# Altium schematic sheet Schematic.SchDoc
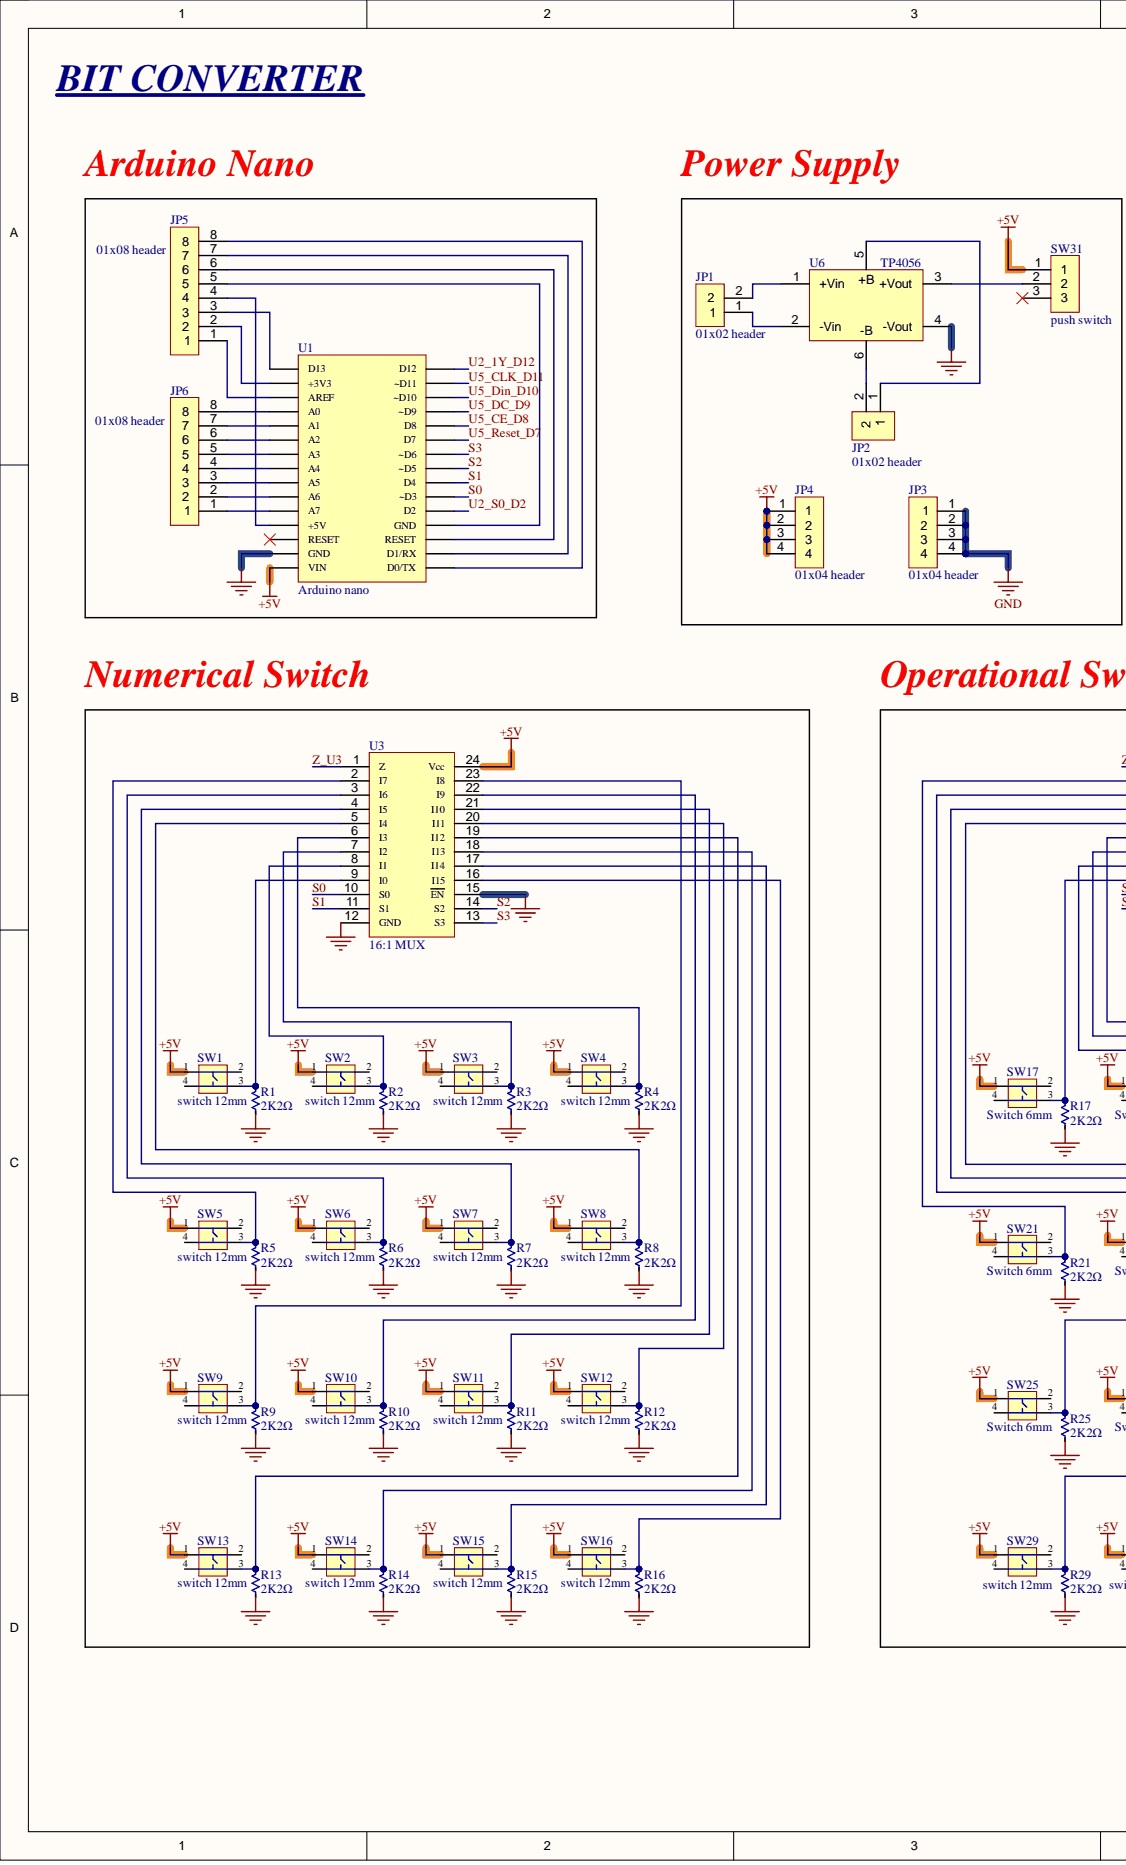
[diagram p0: Schematic.SchDoc, left]
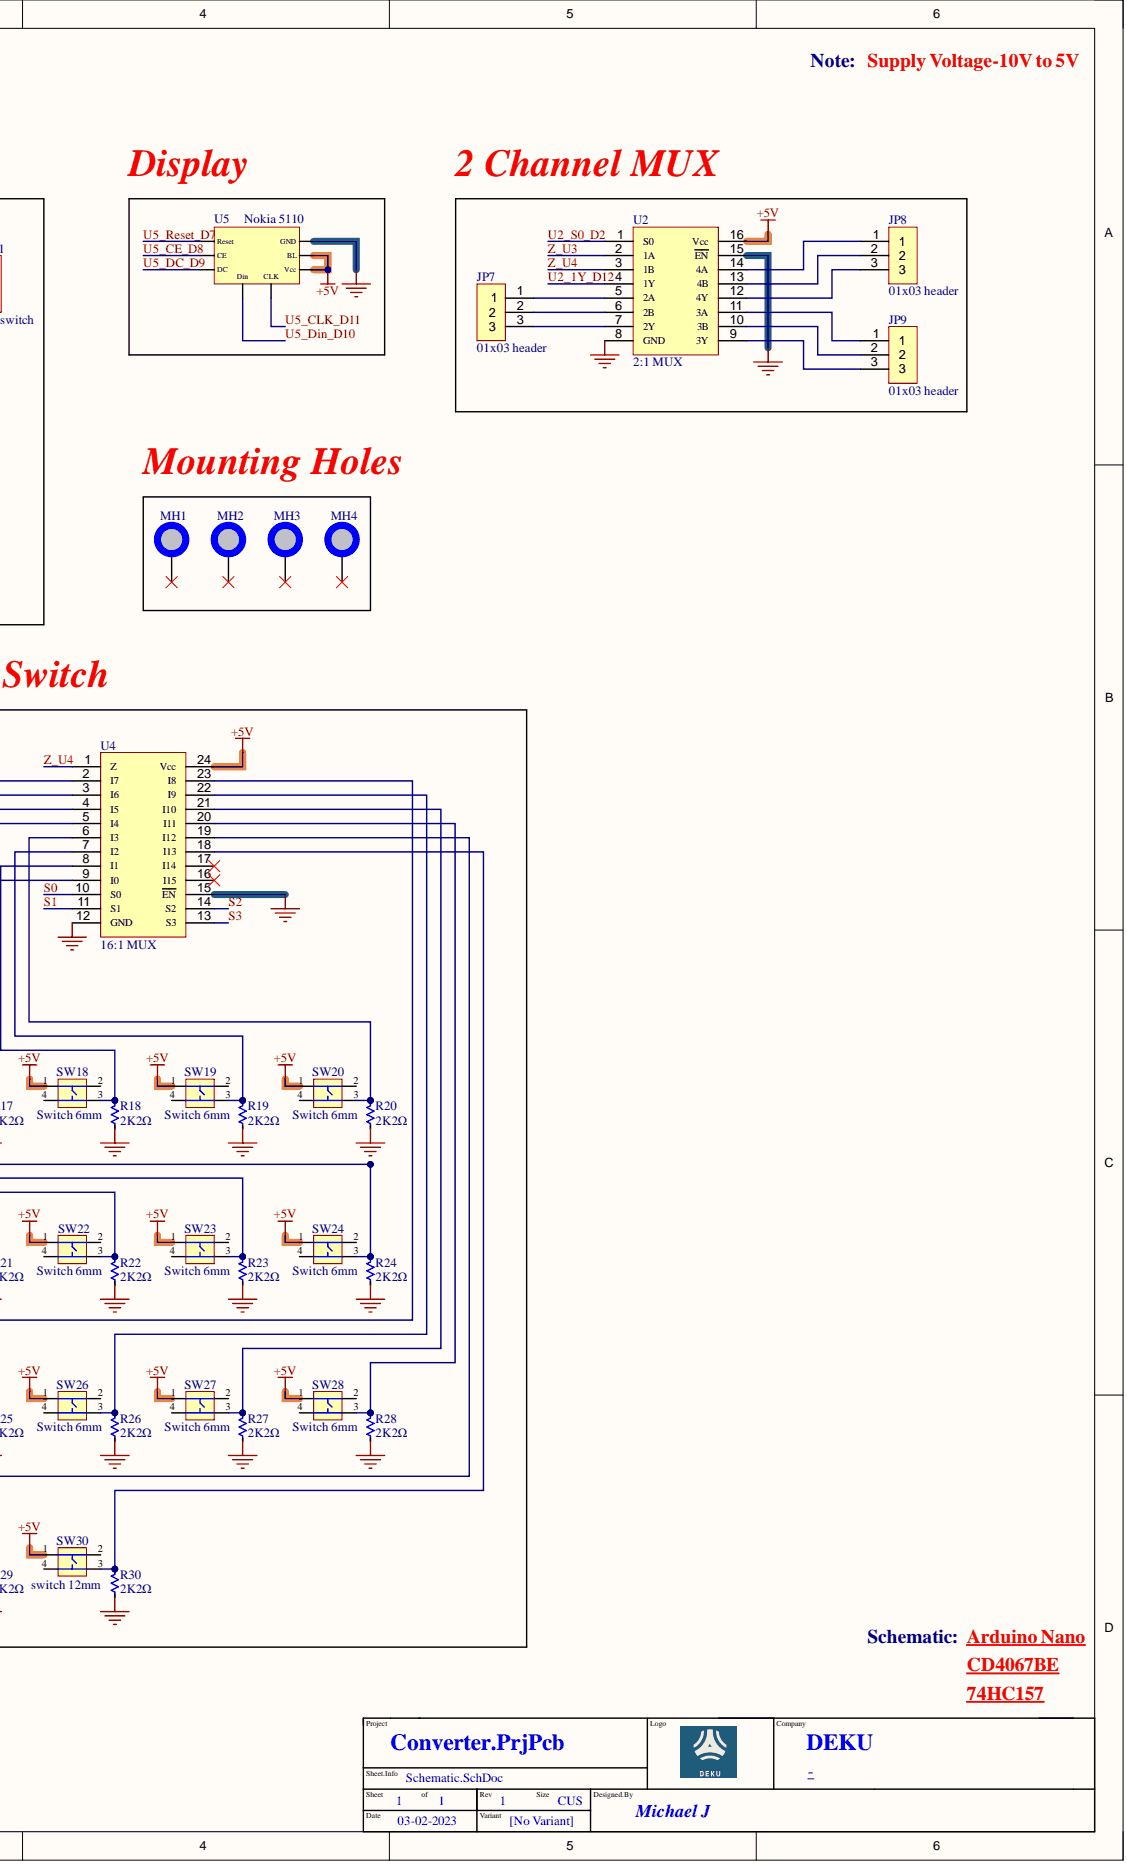
[diagram p1: Schematic.SchDoc, right]

## Parts
JP1  01x02 header  [01x02 header]
JP2  01x02 header  [01x02 header]
JP3  01x04 header  [01x04 header]
JP4  01x04 header  [01x04 header]
JP5  01x08 header  [01x08 header]
JP6  01x08 header  [01x08 header]
JP7  01x03 header  [01x03 header]
JP8  01x03 header  [01x03 header]
JP9  01x03 header  [01x03 header]
MH1  Mounting_Hole_NP_7.4mm_3.2mmNPD  [Mounting_Hole_NP_7.4mm_3.2mmNPD]  (value not drawn)  (no BOM)
MH2  Mounting_Hole_NP_7.4mm_3.2mmNPD  [Mounting_Hole_NP_7.4mm_3.2mmNPD]  (value not drawn)  (no BOM)
MH3  Mounting_Hole_NP_7.4mm_3.2mmNPD  [Mounting_Hole_NP_7.4mm_3.2mmNPD]  (value not drawn)  (no BOM)
MH4  Mounting_Hole_NP_7.4mm_3.2mmNPD  [Mounting_Hole_NP_7.4mm_3.2mmNPD]  (value not drawn)  (no BOM)
R1  2K2Ω  [2.2k]
R2  2K2Ω  [2.2k]
R3  2K2Ω  [2.2k]
R4  2K2Ω  [2.2k]
R5  2K2Ω  [2.2k]
R6  2K2Ω  [2.2k]
R7  2K2Ω  [2.2k]
R8  2K2Ω  [2.2k]
R9  2K2Ω  [2.2k]
R10  2K2Ω  [2.2k]
R11  2K2Ω  [2.2k]
R12  2K2Ω  [2.2k]
R13  2K2Ω  [2.2k]
R14  2K2Ω  [2.2k]
R15  2K2Ω  [2.2k]
R16  2K2Ω  [2.2k]
R17  2K2Ω  [2.2k]
R18  2K2Ω  [2.2k]
R19  2K2Ω  [2.2k]
R20  2K2Ω  [2.2k]
R21  2K2Ω  [2.2k]
R22  2K2Ω  [2.2k]
R23  2K2Ω  [2.2k]
R24  2K2Ω  [2.2k]
R25  2K2Ω  [2.2k]
R26  2K2Ω  [2.2k]
R27  2K2Ω  [2.2k]
R28  2K2Ω  [2.2k]
R29  2K2Ω  [2.2k]
R30  2K2Ω  [2.2k]
SW1  switch 12mm  Length: 12mm  [switch 12mm]
SW2  switch 12mm  Length: 12mm  [switch 12mm]
SW3  switch 12mm  Length: 12mm  [switch 12mm]
SW4  switch 12mm  Length: 12mm  [switch 12mm]
SW5  switch 12mm  Length: 12mm  [switch 12mm]
SW6  switch 12mm  Length: 12mm  [switch 12mm]
SW7  switch 12mm  Length: 12mm  [switch 12mm]
SW8  switch 12mm  Length: 12mm  [switch 12mm]
SW9  switch 12mm  Length: 12mm  [switch 12mm]
SW10  switch 12mm  Length: 12mm  [switch 12mm]
SW11  switch 12mm  Length: 12mm  [switch 12mm]
SW12  switch 12mm  Length: 12mm  [switch 12mm]
SW13  switch 12mm  Length: 12mm  [switch 12mm]
SW14  switch 12mm  Length: 12mm  [switch 12mm]
SW15  switch 12mm  Length: 12mm  [switch 12mm]
SW16  switch 12mm  Length: 12mm  [switch 12mm]
SW17  Switch 6mm  [switch 6mm]
SW18  Switch 6mm  [switch 6mm]
SW19  Switch 6mm  [switch 6mm]
SW20  Switch 6mm  [switch 6mm]
SW21  Switch 6mm  [switch 6mm]
SW22  Switch 6mm  [switch 6mm]
SW23  Switch 6mm  [switch 6mm]
SW24  Switch 6mm  [switch 6mm]
SW25  Switch 6mm  [switch 6mm]
SW26  Switch 6mm  [switch 6mm]
SW27  Switch 6mm  [switch 6mm]
SW28  Switch 6mm  [switch 6mm]
SW29  switch 12mm  Length: 12mm  [switch 12mm]
SW30  switch 12mm  Length: 12mm  [switch 12mm]
SW31  push switch  [push switch]
U1  Arduino nano  Manufacturer: Arduino  [Arduino nano]
U2  2:1 MUX  [2 channel mux]
U3  16:1 MUX  [16 channel mux]
U4  16:1 MUX  [16 channel mux]
U5  Nokia 5110  [nokia 5110]
U6  TP4056  [TP4056]

## Nets
+5V: JP4.1 JP4.2 JP4.3 JP4.4 SW1.1 SW2.1 SW3.1 SW4.1 SW5.1 SW6.1 SW7.1 SW8.1 SW9.1 SW10.1 SW11.1 SW12.1 SW13.1 SW14.1 SW15.1 SW16.1 SW17.1 SW18.1 SW19.1 SW20.1 SW21.1 SW22.1 SW23.1 SW24.1 SW25.1 SW26.1 SW27.1 SW28.1 SW29.1 SW30.1 SW31.1 U1.30(VIN) U2.16(Vcc) U3.24(Vcc) U4.24(Vcc) U5.6(Vcc) U5.7(BL)
GND: JP3.1 JP3.2 JP3.3 JP3.4 R1.1 R2.1 R3.1 R4.1 R5.1 R6.1 R7.1 R8.1 R9.1 R10.1 R11.1 R12.1 R13.1 R14.1 R15.1 R16.1 R17.1 R18.1 R19.1 R20.1 R21.1 R22.1 R23.1 R24.1 R25.1 R26.1 R27.1 R28.1 R29.1 R30.1 U1.29(GND) U2.8(GND) U2.15(EN) U3.12(GND) U3.15(EN) U4.12(GND) U4.15(EN) U5.8(GND) U6.4(-Vout)
S0: U1.6(~D3) U3.10(S0) U4.10(S0)
S1: U1.7(D4) U3.11(S1) U4.11(S1)
S2: U1.8(~D5) U3.14(S2) U4.14(S2)
S3: U1.9(~D6) U3.13(S3) U4.13(S3)
U2_1Y_D12: U1.15(D12) U2.4(1Y)
U2_S0_D2: U1.5(D2) U2.1(S0)
U5_CE_D8: U1.11(D8) U5.2(CE)
U5_CLK_D11: U1.14(~D11) U5.5
U5_DC_D9: U1.12(~D9) U5.3(DC)
U5_Din_D10: U1.13(~D10) U5.4
U5_Reset_D7: U1.10(D7) U5.1(Reset)
Z_U3: U2.2(1A) U3.1(Z)
Z_U4: U2.3(1B) U4.1(Z)
NetJP1_1: JP1.1 U6.2(-Vin)
NetJP1_2: JP1.2 U6.1(+Vin)
NetJP2_1: JP2.1 U6.5(+B)
NetJP2_2: JP2.2 U6.6(-B)
NetJP5_1: JP5.1 U1.18(AREF)
NetJP5_2: JP5.2 U1.17(+3V3)
NetJP5_3: JP5.3 U1.16(D13)
NetJP5_4: JP5.4 U1.27(+5V)
NetJP5_5: JP5.5 U1.4(GND)
NetJP5_6: JP5.6 U1.3(RESET)
NetJP5_7: JP5.7 U1.2(D1/RX)
NetJP5_8: JP5.8 U1.1(D0/TX)
NetJP6_1: JP6.1 U1.26(A7)
NetJP6_2: JP6.2 U1.25(A6)
NetJP6_3: JP6.3 U1.24(A5)
NetJP6_4: JP6.4 U1.23(A4)
NetJP6_5: JP6.5 U1.22(A3)
NetJP6_6: JP6.6 U1.21(A2)
NetJP6_7: JP6.7 U1.20(A1)
NetJP6_8: JP6.8 U1.19(A0)
NetJP7_1: JP7.1 U2.5(2A)
NetJP7_2: JP7.2 U2.6(2B)
NetJP7_3: JP7.3 U2.7(2Y)
NetJP8_1: JP8.1 U2.14(4A)
NetJP8_2: JP8.2 U2.13(4B)
NetJP8_3: JP8.3 U2.12(4Y)
NetJP9_1: JP9.1 U2.11(3A)
NetJP9_2: JP9.2 U2.10(3B)
NetJP9_3: JP9.3 U2.9(3Y)
NetR1_2: R1.2 SW1.3 U3.9(I0)
NetR2_2: R2.2 SW2.3 U3.8(I1)
NetR3_2: R3.2 SW3.3 U3.7(I2)
NetR4_2: R4.2 SW4.3 U3.6(I3)
NetR5_2: R5.2 SW5.3 U3.2(I7)
NetR6_2: R6.2 SW6.3 U3.3(I6)
NetR7_2: R7.2 SW7.3 U3.4(I5)
NetR8_2: R8.2 SW8.3 U3.5(I4)
NetR9_2: R9.2 SW9.3 U3.23(I8)
NetR10_2: R10.2 SW10.3 U3.22(I9)
NetR11_2: R11.2 SW11.3 U3.21(I10)
NetR12_2: R12.2 SW12.3 U3.20(I11)
NetR13_2: R13.2 SW13.3 U3.19(I12)
NetR14_2: R14.2 SW14.3 U3.18(I13)
NetR15_2: R15.2 SW15.3 U3.17(I14)
NetR16_2: R16.2 SW16.3 U3.16(I15)
NetR17_2: R17.2 SW17.3 U4.9(I0)
NetR18_2: R18.2 SW18.3 U4.8(I1)
NetR19_2: R19.2 SW19.3 U4.7(I2)
NetR20_2: R20.2 SW20.3 U4.6(I3)
NetR21_2: R21.2 SW21.3 U4.2(I7)
NetR22_2: R22.2 SW22.3 U4.3(I6)
NetR23_2: R23.2 SW23.3 U4.4(I5)
NetR24_2: R24.2 SW24.3 U4.5(I4)
NetR25_2: R25.2 SW25.3 U4.23(I8)
NetR26_2: R26.2 SW26.3 U4.22(I9)
NetR27_2: R27.2 SW27.3 U4.21(I10)
NetR28_2: R28.2 SW28.3 U4.20(I11)
NetR29_2: R29.2 SW29.3 U4.19(I12)
NetR30_2: R30.2 SW30.3 U4.18(I13)
NetSW31_2: SW31.2 U6.3(+Vout)

Not connected: MH1 1; MH2 1; MH3 1; MH4 1; SW1 2 4; SW2 2 4; SW3 2 4; SW4 2 4; SW5 2 4; SW6 2 4; SW7 2 4; SW8 2 4; SW9 2 4; SW10 2 4; SW11 2 4; SW12 2 4; SW13 2 4; SW14 2 4; SW15 2 4; SW16 2 4; SW17 2 4; SW18 2 4; SW19 2 4; SW20 2 4; SW21 2 4; SW22 2 4; SW23 2 4; SW24 2 4; SW25 2 4; SW26 2 4; SW27 2 4; SW28 2 4; SW29 2 4; SW30 2 4; SW31 3; U1 28(RESET); U4 16(I15) 17(I14)

## Library data of the parts
MH1-MH4 = Mounting_Hole_NP_7.4mm_3.2mmNPD: Mounting_Hole_NonPlated_7.4mm_3.2mmNonPlatedDrill; Library Name: Arduino_Uno_V1.1.IntLib
R1-R30 = 2K2Ω: RES 2.2K OHM 1/4W 5% AXIAL; Manufacturer: Yageo; Manufacturer Part Number: CFR-25JB-52-2K2; Max Power Dissipation: 250mW; Resistance: 2.2kR; Temperature Coefficient: 500ppm/°C; Case/Package: Axial; Category: Through-Hole Resistors; Component Kind: Standard; ComponentLink1Description: http://www.yageo.com/NewPortal/yageodocoutput?fileName=/pdf/throughhole/Yageo_LR_CFR_2013.pdf&src-supplier=Digi-Key; ComponentLink1URL: http://www.yageo.com/NewPortal/yageodocoutput?fileName=/pdf/throughhole/Yageo_LR_CFR_2013.pdf&src-supplier=Digi-Key; Lead Free: Lead Free; Length: 0.248inch; Library Name: Schlib1.SchLib; Max Operating Temperature: 155°C; Min Operating Temperature: -55°C; Mount: Through Hole; Number of Terminations: 2; Package Quantity: 200; Packaging: Bulk; Pricing 1: 1=0.10000, 10=0.06700, 25=0.04640, 50=0.03600, 100=0.02580, 250=0.02008, 500=0.01596, 1000=0.01133, 5000=0.00876 (USD); Resistor Type: Carbon Film; RoHS: Yes; RoHS Compliant: Yes; Series: Carbon Film; Stock 1: 57847; Supplier 1: Digi-Key; Supplier Part Number 1: 2.2KQBK-ND; Tolerance: 5%
SW1-SW16 SW29 SW30 = switch 12mm: Switch Tactile N.O. SPST Flat Plunger PC Pins 0.05A 24VDC 1.27N Thru-Hole Bag; Manufacturer: Omron; Manufacturer Part Number: B3F-5001; Actuator Color: Blue; Actuator Length: 0.8mm; Actuator Type: Standard; Contact Current Rating: 50mA; Contact Resistance: 100mR; Electrical Life: 10000000Cycles; Illumination Type: Non-Illuminated,Illuminated; Max Voltage Rating (DC): 24V; Number of Poles: 1; Ratings: IP00; Switch Function: Off-Mom; Switch Travel: 0.3mm; Category: Tactile Switches; Component Kind: Standard; ComponentLink1Description: https://datasheet.ciiva.com/2901/b3f-0811-2901588.pdf?src-supplier=Digi-Key; ComponentLink1URL: https://datasheet.ciiva.com/2901/b3f-0811-2901588.pdf?src-supplier=Digi-Key; ComponentLink2Description: https://datasheet.ciiva.com/16154/en-b3f-16154917.pdf?src-supplier=Onlinecomponents.com; ComponentLink2URL: https://datasheet.ciiva.com/16154/en-b3f-16154917.pdf?src-supplier=Onlinecomponents.com; ComponentLink3Description: https://datasheet.ciiva.com/30248/en-b3f-30248566.pdf?src-supplier=Onlinecomponents.com; ComponentLink3URL: https://datasheet.ciiva.com/30248/en-b3f-30248566.pdf?src-supplier=Onlinecomponents.com; ComponentLink4Description: https://datasheet.ciiva.com/1532/611570-1532602.pdf?src-supplier=Element14; ComponentLink4URL: https://datasheet.ciiva.com/1532/611570-1532602.pdf?src-supplier=Element14; Contact Material: Gold,Silver; Contact Plating: Silver,Gold; Current Rating: 50mA; Height: 4.3mm; Lead Free: Lead Free; Library Name: Schlib1.SchLib; Max Operating Temperature: 70°C; Mechanical Life: 10000000Cycles; Min Operating Temperature: -25°C; Mount: Through Hole; Operating Force: 1.27N; Orientation: Straight; Package Quantity: 500; Packaging: Bulk; Pricing 1: 1=2.75000, 10=2.58900, 25=2.35320, 100=2.03950, 250=1.96104 (USD); REACH SVHC: Unknown; RoHS: Yes; RoHS Compliant: Yes; Series: B3F; Stock 1: 393; Supplier 1: Digi-Key; Supplier Part Number 1: SW968-ND; Termination: PC Pin; Throw Configuration: SPST; Voltage Rating (DC): 24V; Weight: 0.85g; Width: 12mm
SW17-SW28 = switch 6mm: APEM - MJTP1230 - SWITCH, TACTILE SPST, 50mA, THROUGH HOLE; Actuator Color: Black; Actuator Length: 0.7mm; Actuator Material: Thermoplastic; Actuator Type: Standard; Contact Resistance: 100mR; Electrical Life: 100000Cycles; Illumination Type: Non-Illuminated,Illuminated; Max Voltage Rating (DC): 12V; Operating Force: 160gf; Switch Function: Off-Mom; Switch Travel: 0.25mm; Category: Tactile Switches; Color: Black; Component Kind: Standard; ComponentLink1Description: https://datasheet.ciiva.com/1701/1598766-1701378.pdf?src-supplier=Newark; ComponentLink1URL: https://datasheet.ciiva.com/1701/1598766-1701378.pdf?src-supplier=Newark; ComponentLink2Description: https://datasheet.ciiva.com/16155/mjtp-6mm-16155869.pdf?src-supplier=Onlinecomponents.com; ComponentLink2URL: https://datasheet.ciiva.com/16155/mjtp-6mm-16155869.pdf?src-supplier=Onlinecomponents.com; Contact Material: Bronze,Stainless Steel; Contact Plating: Silver; Current Rating: 50mA; Library Name: Schlib1.SchLib; Manufacturer: APEM; Manufacturer Part Number: MJTP1230; Max Operating Temperature: 70°C; Mechanical Life: 100000Cycles; Min Operating Temperature: -20°C; Mount: Through Hole; Orientation: Straight; Package Quantity: 100; Packaging: Bulk; Pricing 1: 1=0.10000, 10=0.09100, 25=0.08600, 100=0.07830, 250=0.07356, 500=0.06732, 1000=0.05636, 2500=0.05479, 5000=0.05166 (USD); REACH SVHC: No SVHC; RoHS: Yes; RoHS Compliant: Yes; Series: MJTP; Stock 1: 77193; Supplier 1: Digi-Key; Supplier Part Number 1: 679-2428-ND; Termination: PC Pin; Throw Configuration: SPST; Voltage Rating (DC): 12V
U1 = Arduino nano: ARDUINO - A000005 - Development Board, Arduino Nano, ATmega328 MCU, 14 3.3V I/O, 6 PWM Outputs, USB Mini B; Core Architecture: AVR; Data Bus Width: 8b; Evaluation Kit: Yes; Interface: I2C,SPI,USB; Number of Bits: 8; Operating Supply Voltage: 20V; Category: Embedded Processors and Controllers; Component Kind: Standard; ComponentLink1Description: https://datasheet.ciiva.com/2398/arduinonanomanual23-2398236.pdf?src-supplier=Digi-Key; ComponentLink1URL: https://datasheet.ciiva.com/2398/arduinonanomanual23-2398236.pdf?src-supplier=Digi-Key; ComponentLink2Description: https://datasheet.ciiva.com/19455/2121148-19455443.pdf?src-supplier=Jameco; ComponentLink2URL: https://datasheet.ciiva.com/19455/2121148-19455443.pdf?src-supplier=Jameco; ComponentLink3Description: https://datasheet.ciiva.com/1336/1682238-1336473.pdf?src-supplier=Element14; ComponentLink3URL: https://datasheet.ciiva.com/1336/1682238-1336473.pdf?src-supplier=Element14; ComponentLink4Description: https://datasheet.ciiva.com/1414/810078-1414124.pdf?src-supplier=Newark; ComponentLink4URL: https://datasheet.ciiva.com/1414/810078-1414124.pdf?src-supplier=Newark; ComponentLink5Description: https://datasheet.ciiva.com/4926/arduino-arduinoboardnano-188748-4926316.pdf?src-supplier=Mouser; ComponentLink5URL: https://datasheet.ciiva.com/4926/arduino-arduinoboardnano-188748-4926316.pdf?src-supplier=Mouser; ComponentLink6Description: https://datasheet.ciiva.com/20168/73-4448-20168542.pdf?src-supplier=Rapid+Electronics; ComponentLink6URL: https://datasheet.ciiva.com/20168/73-4448-20168542.pdf?src-supplier=Rapid+Electronics; Height: 0.25inch; Length: 7inch; Library Name: Schlib1.SchLib; Manufacturer Part Number: A000005; Package Quantity: 1; Packaging: Bulk; Pricing 1: 1=22.00000, 10=20.90000, 100=19.80010, 500=18.70012 (USD); REACH SVHC: No SVHC; RoHS: Yes; Stock 1: 4251; Supplier 1: Digi-Key; Supplier Part Number 1: 1050-1001-ND; Weight: 0.02lb; Width: 5inch
U2 = 74HC157: Multiplexer, HC/UH Series, 4-Func, 2 Line Input, 1 Line Output, True Output, CMOS, PDIP16; Manufacturer: Texas Instruments; Manufacturer Part Number: SN74HC157N; Bandwidth: 28MHz; High Level Output Current: 7.8mA; Independent Circuits: 1; Max Power Dissipation: 1.25W; Max Supply Voltage: 6V; Min Supply Voltage: 2V; Number of Bits: 2; Number of Channels: 4; Number of Inputs: 8; Operating Supply Voltage: 5V; Polarity: Non-Inverting; Propagation Delay: 32ns; Supply Type: Single; Throw Configuration: DPST; Case/Package: PDIP; Category: Decoders and Multiplexers; Component Kind: Standard; ComponentLink1Description: https://datasheet.ciiva.com/15087/getdatasheetpartid-83241-15087122.pdf?src-supplier=Verical; ComponentLink1URL: https://datasheet.ciiva.com/15087/getdatasheetpartid-83241-15087122.pdf?src-supplier=Verical; ComponentLink2Description: https://datasheet.ciiva.com/41456/001016668-da-01-en-ic-qua-2-1-data-s-sn74hc157n-pdip-16-tid-41456963.pdf?src-supplier=Conrad; ComponentLink2URL: https://datasheet.ciiva.com/41456/001016668-da-01-en-ic-qua-2-1-data-s-sn74hc157n-pdip-16-tid-41456963.pdf?src-supplier=Conrad; ComponentLink3Description: https://datasheet.ciiva.com/1509/1834291-1509008.pdf?src-supplier=Element14; ComponentLink3URL: https://datasheet.ciiva.com/1509/1834291-1509008.pdf?src-supplier=Element14; ComponentLink4Description: https://datasheet.ciiva.com/26037/scls113d-26037945.pdf?src-supplier=Avnet+Europe; ComponentLink4URL: https://datasheet.ciiva.com/26037/scls113d-26037945.pdf?src-supplier=Avnet+Europe; ComponentLink5Description: https://datasheet.ciiva.com/1890/sn74hc157-1890959.pdf?src-supplier=Digi-Key; ComponentLink5URL: https://datasheet.ciiva.com/1890/sn74hc157-1890959.pdf?src-supplier=Digi-Key; Contact Plating: Gold; Lead Free: Lead Free; Library Name: Schlib1.SchLib; Logic Function: Multiplexer; Low Level Output Current: 7.8mA; Max Operating Temperature: 85°C; Min Operating Temperature: -40°C; Mount: Through Hole; Number of Circuits: 4; Number of Input Lines: 2; Number of Output Lines: 1; Number of Outputs: 4; Number of Pins: 16; Package Quantity: 25; Packaging: Rail/Tube; Pricing 1: 1=0.60000, 10=0.51300, 25=0.47840, 100=0.38290, 250=0.35552, 500=0.30082, 1000=0.23246, 2500=0.22575 (USD); Radiation Hardening: No; REACH SVHC: No SVHC; RoHS: Yes; RoHS Compliant: Yes; Series: 74HC; Stock 1: 5098; Supplier 1: Digi-Key; Supplier Part Number 1: 296-1578-5-ND; Weight: 0.03357oz
U3 U4 = CD4067BE: TEXAS INSTRUMENTS      CD4067BE      IC, ANALOG MUX/DMUX, 16 X 1, DIP-24; Bandwidth: 14MHz; Input Capacitance: 5pF; Logic Function: Multiplexer,Demultiplexer,Mux; Max Input Voltage: 15V; Max Power Dissipation: 500mW; Max Supply Voltage: 18V; Min Supply Voltage: 3V; Nominal Supply Current: 5uA; Number of Bits: 16; Number of Channels: 1; Number of Terminals/Pins: 24; On-State Resistance: 240R; Operating Supply Current: 80nA; Package Body Material: Plastic; Polarity: Non-Inverting; Propagation Delay: 60ns; Supply Type: Single; Surface Mount: No; Turn-Off Delay Time: 440ns; Turn-On Delay Time: 650ns; Case/Package: PDIP; Category: Decoders and Multiplexers; Component Kind: Standard; ComponentLink1Description: https://datasheet.ciiva.com/27050/818363-27050195.pdf?src-supplier=Jameco; ComponentLink1URL: https://datasheet.ciiva.com/27050/818363-27050195.pdf?src-supplier=Jameco; ComponentLink2Description: https://datasheet.ciiva.com/14134/cd4067b-eng-tds-14134583.pdf?src-supplier=Distrelec; ComponentLink2URL: https://datasheet.ciiva.com/14134/cd4067b-eng-tds-14134583.pdf?src-supplier=Distrelec; ComponentLink3Description: https://datasheet.ciiva.com/15127/getdatasheetpartid-187037-15127402.pdf?src-supplier=Verical; ComponentLink3URL: https://datasheet.ciiva.com/15127/getdatasheetpartid-187037-15127402.pdf?src-supplier=Verical; ComponentLink4Description: https://datasheet.ciiva.com/29297/173320-da-01-en-cmos-ic-cd-4067-be-dip24-tid-29297354.pdf?src-supplier=Conrad; ComponentLink4URL: https://datasheet.ciiva.com/29297/173320-da-01-en-cmos-ic-cd-4067-be-dip24-tid-29297354.pdf?src-supplier=Conrad; ComponentLink5Description: https://datasheet.ciiva.com/1514/1850989-1514375.pdf?src-supplier=Element14; ComponentLink5URL: https://datasheet.ciiva.com/1514/1850989-1514375.pdf?src-supplier=Element14; ComponentLink6Description: https://datasheet.ciiva.com/26025/cd4097b-26025207.pdf?src-supplier=Avnet+Europe; ComponentLink6URL: https://datasheet.ciiva.com/26025/cd4097b-26025207.pdf?src-supplier=Avnet+Europe; ComponentLink7Description: https://datasheet.ciiva.com/1675/cd4067b-1675563.pdf?src-supplier=Digi-Key; ComponentLink7URL: https://datasheet.ciiva.com/1675/cd4067b-1675563.pdf?src-supplier=Digi-Key; ComponentLink8Description: https://datasheet.ciiva.com/2978/cd4097b-mil-2978699.pdf?src-supplier=Avnet; ComponentLink8URL: https://datasheet.ciiva.com/2978/cd4097b-mil-2978699.pdf?src-supplier=Avnet; Contact Plating: Gold; JESD-30 Code: R-PDIP-T24; Lead Free: Lead Free; Library Name: Schlib1.SchLib; Manufacturer: Texas Instruments; Manufacturer Part Number: CD4067BE; Max Input Voltage (AC): 15V; Max Operating Temperature: 125°C; Min Operating Temperature: -55°C; Mount: Through Hole; Number of Circuits: 1; Number of Functions: 1; Number of Inputs: 16; Number of Outputs: 1; Number of Pins: 24; Number of Switches: 1; Package Code: DIP; Package Quantity: 15; Package Shape: Rectangular; Packaging: Rail/Tube; Power Dissipation: 500mW; Quiescent Current: 80nA; Radiation Hardening: No; REACH SVHC: No SVHC; RoHS: Yes; RoHS Compliant: Yes; Series: 4000B; Supplier 1: Newark; Supplier Part Number 1: 60K5125; Supply Current: 5uA; Technology: CMOS; Temperature Grade: MILITARY; Terminal Finish: Gold; Terminal Form: Through Hole; Terminal Position: DUAL; Weight: 0.131759oz; Width: 15.24mm
U5 = Nokia 5110: Adafruit Nokia 5110 / 3310 Monochrome LCD and Extras; Manufacturer: Adafruit Industries; Manufacturer Part Number: 338; Resolution (height): 48pixel; Resolution (width): 84pixel; Category: LCD, OLED, Graphic Displays; Component Kind: Standard; ComponentLink1Description: https://cdn-learn.adafruit.com/downloads/pdf/nokia-5110-3310-monochrome-lcd.pdf?src-supplier=Digi-Key; ComponentLink1URL: https://cdn-learn.adafruit.com/downloads/pdf/nokia-5110-3310-monochrome-lcd.pdf?src-supplier=Digi-Key; Display Type: LCD; Library Name: Schlib1.SchLib; Pricing 1: 1=10.00000 (USD); RoHS: Yes; Stock 1: 29; Supplier 1: Newark; Supplier Part Number 1: 53W5865
JP1 JP2 = 01x02 header: Component Kind: Standard; Library Name: Schlib1.SchLib
JP3 JP4 = 01x04 header: Component Kind: Standard; Library Name: Schlib1.SchLib
JP5 JP6 = 01x08 header: Component Kind: Standard; Library Name: Schlib1.SchLib
JP7-JP9 = 01x03 header: Component Kind: Standard; Library Name: Schlib1.SchLib
SW31 = push switch: Component Kind: Standard; Library Name: Schlib1.SchLib
U6 = TP4056: Component Kind: Standard; Library Name: Schlib1.SchLib
